# Altium schematic sheet DE-180824_1.SchDoc
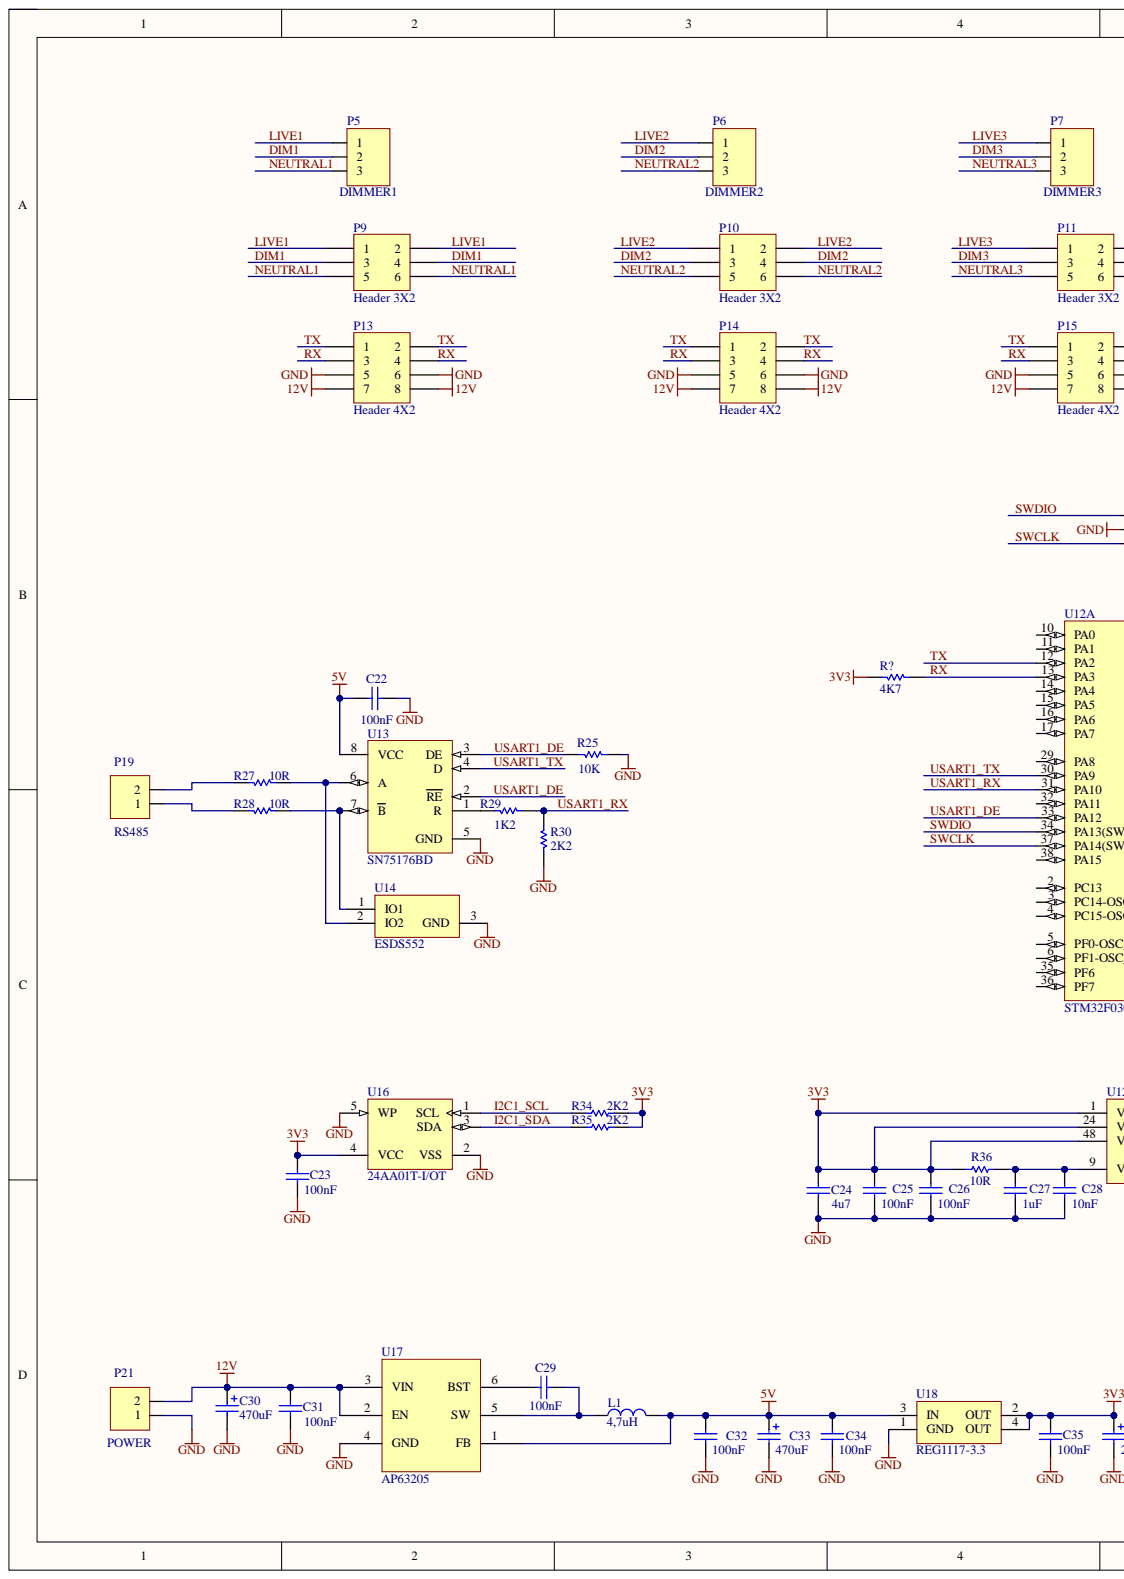
[diagram p0: DE-180824_1.SchDoc, left]
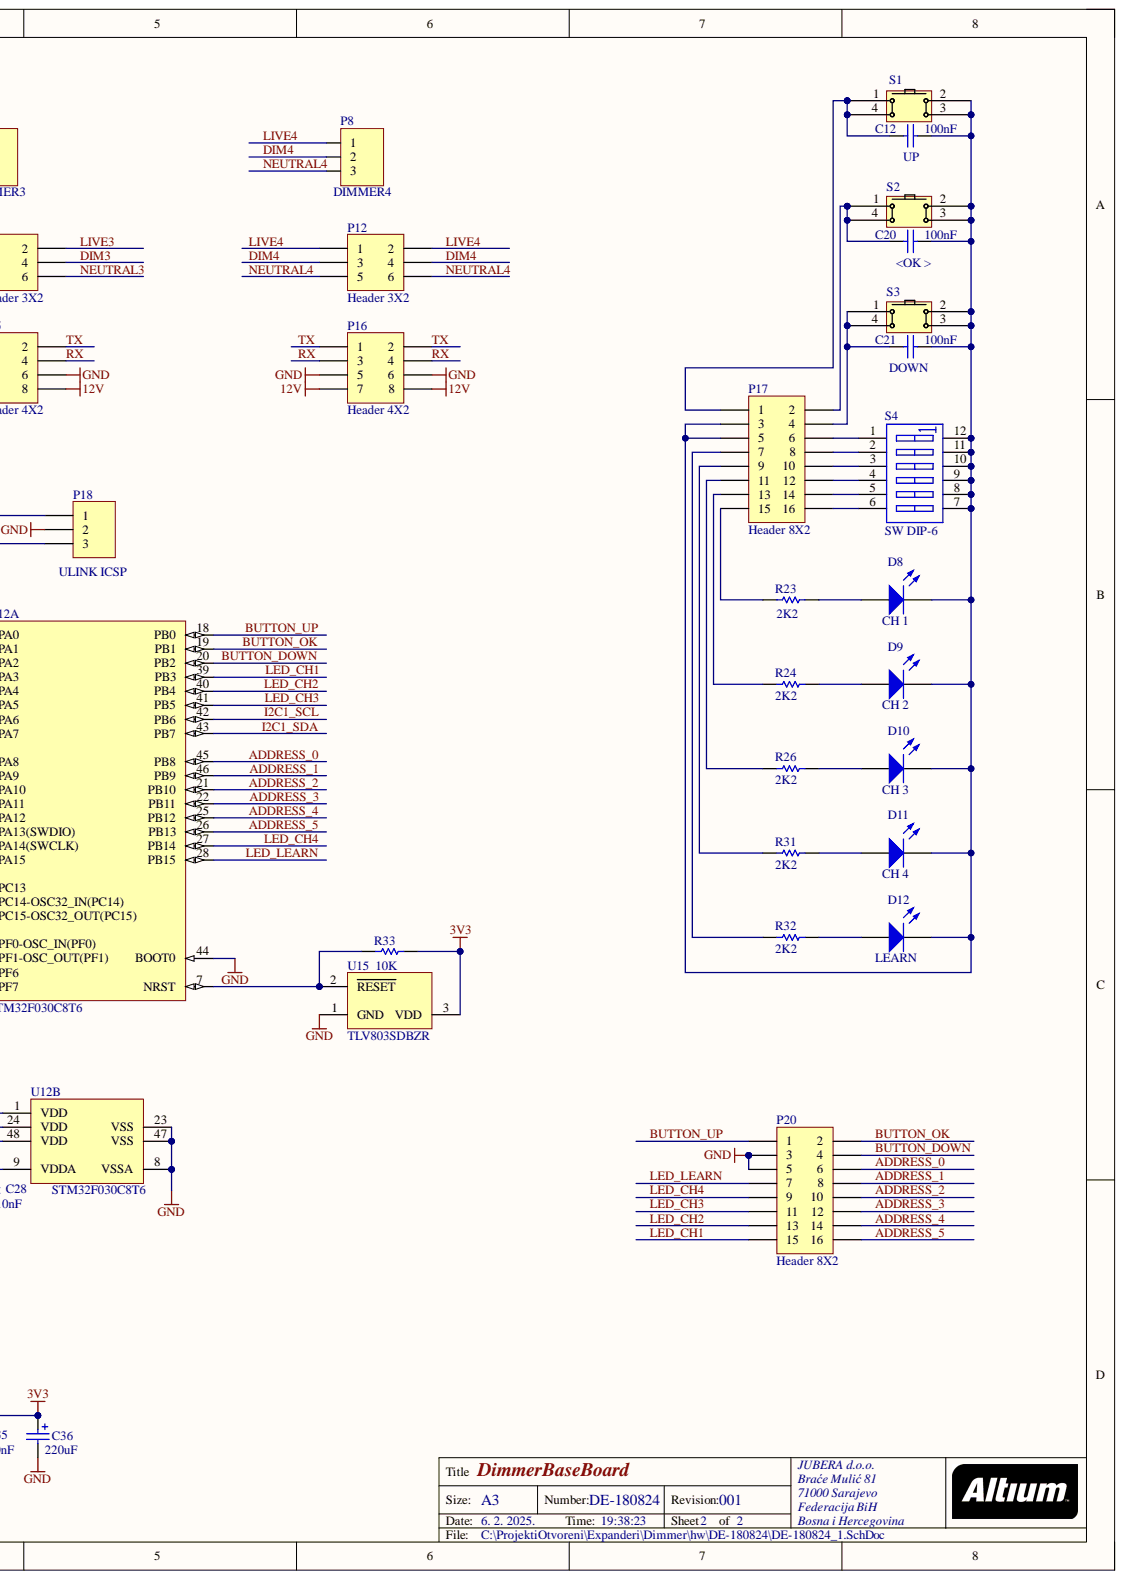
[diagram p1: DE-180824_1.SchDoc, right]

## Parts
C12  value 100nF  [0603]  (hidden comment: C0603)
C20  value 100nF  [0603]  (hidden comment: C0603)
C21  value 100nF  [0603]  (hidden comment: C0603)
C22  100nF  [0603]
C23  value 100nF  [0603]
C24  4u7  [0805]
C25  100nF  [0603]
C26  100nF  [0603]
C27  1uF  [0603]
C28  10nF  [0603]
C29  value 100nF  [0603]
C30  470uF  [ROQANG VT1V471M1010]
C31  value 100nF  [0603]
C32  value 100nF  [0603]
C33  470uF  [SMD,D6.3xL7.7mm Aluminum Electrolytic Capacitors]
C34  value 100nF  [0603]
C35  value 100nF  [0603]
C36  value 220uF  [SMD,D6.3xL7.7mm Aluminum Electrolytic Capacitors]
D8  CH 1  [1.6X0.8X0.8]
D9  CH 2  [1.6X0.8X0.8]
D10  CH 3  [1.6X0.8X0.8]
D11  CH 4  [1.6X0.8X0.8]
D12  LEARN  [1.6X0.8X0.8]
L1  value 4,7uH  [XRNR4030-4.7uH/M]
P5  DIMMER1  [TERMINAL BLOK 3]
P6  DIMMER2  [TERMINAL BLOK 3]
P7  DIMMER3  [TERMINAL BLOK 3]
P8  DIMMER4  [TERMINAL BLOK 3]
P9  Header 3X2  [HDR2X3]
P10  Header 3X2  [HDR2X3]
P11  Header 3X2  [HDR2X3]
P12  Header 3X2  [HDR2X3]
P13  Header 4X2  [HDR2X4]
P14  Header 4X2  [HDR2X4]
P15  Header 4X2  [HDR2X4]
P16  Header 4X2  [HDR2X4]
P17  Header 8X2  [HDR2X8]
P18  ULINK ICSP  [HDR1X3]
P19  RS485  [TERMINAL BLOK 2]
P20  Header 8X2  [HDR2X8]
P21  POWER  [TERMINAL BLOK 2]
R23  2K2  [ERA-0603]
R24  2K2  [ERA-0603]
R25  10K  [ERA-0603]
R26  2K2  [ERA-0603]
R27  10R  [ERA-0603]
R28  10R  [ERA-0603]
R29  1K2  [ERA-0603]
R30  2K2  [ERA-0603]
R31  2K2  [ERA-0603]
R32  2K2  [ERA-0603]
R33  10K  [ERA-0603]
R34  2K2  [ERA-0603]
R35  2K2  [ERA-0603]
R36  10R  [ERA-0603]
R?  value 4K7  [ERA-0603]  (hidden comment: 10K)
S1  UP  [TACTILE_SWITCH]
S2  <OK >  [TACTILE_SWITCH]
S3  DOWN  [TACTILE_SWITCH]
S4  SW DIP-6  [DIP-12/SW]
U12  STM32F030C8T6  [STM-LQFP48_N]  (parts A,B)
U13  SN75176BD  [D008_N]
U14  ESDS552  [DCK3_N]
U15  TLV803SDBZR  [DBZ3_N]
U16  24AA01T-I/OT  [SOT-23-OT5_N]
U17  AP63205  [TSOT-26]
U18  REG1117-3.3  [ZZ311]

## Nets
3V3: C23.2 C24.1 C25.1 C26.1 C35.2 C36.1 R33.2 R34.2 R35.2 R36.2 R?.1 U12.1(VDD) U12.24(VDD) U12.48(VDD) U15.3 U16.4(VCC) U18.2(OUT) U18.4(OUT)
5V: C22.1 C32.2 C33.1 C34.2 L1.1 U13.8(VCC) U17.1(FB) U18.3(IN)
12V: C30.1 C31.2 P13.7 P13.8 P14.7 P14.8 P15.7 P15.8 P16.7 P16.8 P21.2 U17.2(EN) U17.3(VIN)
GND: C22.2 C23.1 C24.2 C25.2 C26.2 C27.2 C28.2 C30.2 C31.1 C32.1 C33.2 C34.1 C35.1 C36.2 P13.5 P13.6 P14.5 P14.6 P15.5 P15.6 P16.5 P16.6 P18.2 P20.3 P20.5 P21.1 R25.1 R30.2 U12.8(VSSA) U12.23(VSS) U12.44(BOOT0) U12.47(VSS) U13.5(GND) U14.3 U15.1 U16.2(VSS) U16.5(WP) U17.4(GND) U18.1(GND)
ADDRESS_0: P20.6 U12.45(PB8)
ADDRESS_1: P20.8 U12.46(PB9)
ADDRESS_2: P20.10 U12.21(PB10)
ADDRESS_3: P20.12 U12.22(PB11)
ADDRESS_4: P20.14 U12.25(PB12)
ADDRESS_5: P20.16 U12.26(PB13)
BUTTON_DOWN: P20.4 U12.20(PB2)
BUTTON_OK: P20.2 U12.19(PB1)
BUTTON_UP: P20.1 U12.18(PB0)
DIM1: P5.2 P9.3 P9.4
DIM2: P6.2 P10.3 P10.4
DIM3: P7.2 P11.3 P11.4
DIM4: P8.2 P12.3 P12.4
I2C1_SCL: R34.1 U12.42(PB6) U16.1(SCL)
I2C1_SDA: R35.1 U12.43(PB7) U16.3(SDA)
LED_CH1: P20.15 U12.39(PB3)
LED_CH2: P20.13 U12.40(PB4)
LED_CH3: P20.11 U12.41(PB5)
LED_CH4: P20.9 U12.27(PB14)
LED_LEARN: P20.7 U12.28(PB15)
LIVE1: P5.1 P9.1 P9.2
LIVE2: P6.1 P10.1 P10.2
LIVE3: P7.1 P11.1 P11.2
LIVE4: P8.1 P12.1 P12.2
NEUTRAL1: P5.3 P9.5 P9.6
NEUTRAL2: P6.3 P10.5 P10.6
NEUTRAL3: P7.3 P11.5 P11.6
NEUTRAL4: P8.3 P12.5 P12.6
RX: P13.3 P13.4 P14.3 P14.4 P15.3 P15.4 P16.3 P16.4 R?.2 U12.13(PA3)
SWCLK: P18.3 U12.37(PA14(SWCLK))
SWDIO: P18.1 U12.34(PA13(SWDIO))
TX: P13.1 P13.2 P14.1 P14.2 P15.1 P15.2 P16.1 P16.2 U12.12(PA2)
USART1_DE: R25.2 U12.33(PA12) U13.2(RE) U13.3(DE)
USART1_RX: R29.1 R30.1 U12.31(PA10)
USART1_TX: U12.30(PA9) U13.4(D)
NetC12_1: C12.1 P17.1 S1.1 S1.4
NetC12_2: C12.2 C20.2 C21.2 D8.2 D9.2 D10.2 D11.2 D12.2 P17.3 P17.5 S1.2 S1.3 S2.2 S2.3 S3.2 S3.3 S4.7 S4.8 S4.9 S4.10 S4.11 S4.12
NetC20_1: C20.1 P17.2 S2.1 S2.4
NetC21_1: C21.1 P17.4 S3.1 S3.4
NetC27_1: C27.1 C28.1 R36.1 U12.9(VDDA)
NetC29_1: C29.1 L1.2 U17.5(SW)
NetC29_2: C29.2 U17.6(BST)
NetD8_1: D8.1 R23.2
NetD9_1: D9.1 R24.2
NetD10_1: D10.1 R26.2
NetD11_1: D11.1 R31.2
NetD12_1: D12.1 R32.2
NetP17_6: P17.6 S4.1
NetP17_7: P17.7 R32.1
NetP17_8: P17.8 S4.2
NetP17_9: P17.9 R31.1
NetP17_10: P17.10 S4.3
NetP17_11: P17.11 R26.1
NetP17_12: P17.12 S4.4
NetP17_13: P17.13 R24.1
NetP17_14: P17.14 S4.5
NetP17_15: P17.15 R23.1
NetP17_16: P17.16 S4.6
NetP19_1: P19.1 R28.1
NetP19_2: P19.2 R27.1
NetR27_2: R27.2 U13.6(A) U14.2
NetR28_2: R28.2 U13.7(B) U14.1
NetR29_2: R29.2 U13.1(R)
NetR33_1: R33.1 U12.7(NRST) U15.2

Not connected: U12 2(PC13) 3(PC14-OSC32_IN(PC14)) 4(PC15-OSC32_OUT(PC15)) 5(PF0-OSC_IN(PF0)) 6(PF1-OSC_OUT(PF1)) 10(PA0) 11(PA1) 14(PA4) 15(PA5) 16(PA6) 17(PA7) 29(PA8) 32(PA11) 35(PF6) 36(PF7) 38(PA15)

## Library data of the parts
C12 C20-C23 C25-C28 C31 C35 = C0603: Ceramic Chip Capacitor - Standard; Note: Footprint corresponds to dimensions for bulk cassette packaging. This case size has tightened tolerances.; Code_IPC: 1608 [0603]; Code_JEITA: 0603; Component Kind: Standard; ComponentLink1Description: Manufacturer Link; ComponentLink1URL: http://www.kemet.com/; ComponentLink2Description: Datasheet; ComponentLink2URL: http://www.kemet.com/kemet/web/homepage/kechome.nsf/vapubfilesname/F3102TA.pdf/$file/F3102TA.pdf; DatasheetDocument: Mar-2001; LatestRevisionDate: 19-Mar-2004; LatestRevisionNote: Silkscreen size reduced.; PackageDocument: Mar-2001; PackageReference: 0603; Published: 17-Jul-2002; Signal Integrity: C0603; Simulation: CAP; Publisher: Altium Limited
  C12 C20 C21 C23 C31 C35: Library Name: DE-180824.SchLib
  C22: Library Name: DE-220817.SchLib; Value: 100nF
  C25 C26: Library Name: KEMET Chip Capacitor.IntLib; Value: 100nF
  C27: Library Name: KEMET Chip Capacitor.IntLib; Value: 1uF
  C28: Library Name: KEMET Chip Capacitor.IntLib; Value: 10nF
C24 = C0805: Ceramic Chip Capacitor - Standard; Note: Footprint corresponds to dimensions for bulk cassette packaging. This case size has tightened tolerances.; Code_IPC: 2012[0805]; Code_JEITA: 0805; Component Kind: Standard; ComponentLink1Description: Manufacturer Link; ComponentLink1URL: http://www.kemet.com/; ComponentLink2Description: Datasheet; ComponentLink2URL: http://www.kemet.com/kemet/web/homepage/kechome.nsf/vapubfilesname/F3102TA.pdf/$file/F3102TA.pdf; DatasheetDocument: Mar-2001; LatestRevisionDate: 19-Mar-2004; LatestRevisionNote: Silkscreen size reduced.; Library Name: KEMET Chip Capacitor.IntLib; PackageDocument: Mar-2001; PackageReference: 0805; Published: 17-Jul-2002; Signal Integrity: C0805; Simulation: CAP; Value: 4u7; Publisher: Altium Limited
C29 C32 C34 = C0603: Ceramic Chip Capacitor - 25V; Note: Footprint corresponds to dimensions for bulk cassette packaging. This case size has tightened tolerances.; Code_IPC: 1608 [0603]; Code_JEITA: 0603; Component Kind: Standard; ComponentLink1Description: Manufacturer Link; ComponentLink1URL: http://www.kemet.com/; ComponentLink2Description: Datasheet; ComponentLink2URL: http://www.kemet.com/kemet/web/homepage/kechome.nsf/vapubfilesname/F3102TA.pdf/$file/F3102TA.pdf; DatasheetDocument: Mar-2001; LatestRevisionDate: 19-Mar-2004; LatestRevisionNote: Silkscreen size reduced.; Library Name: DE-180824.SchLib; PackageDocument: Mar-2001; PackageReference: 0603; Published: 17-Jul-2002; Signal Integrity: C0603; Simulation: CAP; Publisher: Altium Limited
C30 = ROQANG VT1C221M0607: 220uF 16V 110mA@120Hz ±20% SMD,D6.3xL7.7mm Aluminum Electrolytic Capacitors - SMD ROHS; DrillSize: Nominal, Rounded To The Nearest 0.1mm; Note: Refer to Manufacturer's Data Sheets for other lead formations; Value: 10uF; Component Kind: Standard; ComponentLink1Description: Manufacturer Link; ComponentLink1URL: http://www.vishay.com/; ComponentLink2Description: Datasheet; ComponentLink2URL: http://www.vishay.com/docs/25014/eka.pdf; DatasheetDocument: 20-Feb-2001; Library Name: DE-180824.SchLib; PackageReference: CAPPR2-5X11; Published: 19-Mar-2004; Publisher: Altium Limited
C33 C36 = ROQANG VT1C221M0607: 220uF 16V 110mA@120Hz ±20% SMD,D6.3xL7.7mm Aluminum Electrolytic Capacitors - SMD ROHS; DrillSize: Nominal, Rounded To The Nearest 0.1mm; Note: Refer to Manufacturer's Data Sheets for other lead formations; Component Kind: Standard; ComponentLink1Description: Manufacturer Link; ComponentLink1URL: http://www.vishay.com/; ComponentLink2Description: Datasheet; ComponentLink2URL: http://www.vishay.com/docs/25014/eka.pdf; DatasheetDocument: 20-Feb-2001; Library Name: DE-180824.SchLib; PackageReference: CAPPR2-5X11; Published: 19-Mar-2004; Publisher: Altium Limited
  C33: Value: 470uF
D8-D12 = HSMZ-C190: High Performance Chip LED, Red; LuminousIntensity: 40mcd (Minimum).; PCB_Layout: Complies with manufacturer's recommendation.; Component Kind: Standard; ComponentLink1Description: Manufacturer Link; ComponentLink1URL: http://www.agilent.com/; ComponentLink2Description: Datasheet; ComponentLink2URL: http://cp.literature.agilent.com/litweb/pdf/5988-5891EN.pdf; DatasheetDocument: 11-Mar-2002; LED_Colour: Red; Library Name: DE-180824.SchLib; PackageDocument: 11-Mar-2002; PackageReference: 1.6X0.8X0.8; Published: 11-Dec-2002; Signal Integrity: LED; Simulation: Agl_HSMZC110; Publisher: Altium Limited
L1 = Inductor: 2A 4.7uH Magnetic Adhesive Inductor ±20% 2.9A SMD-2P,4x4mm Power Inductors ROHS; Component Kind: Standard; Library Name: DE-180824.SchLib
P5-P8 = TERMINAL BLOK 3: 3 pinski terminal blok konektor; Component Kind: Standard; Library Name: DE-180824.SchLib
P9-P12 = Header 3X2: Header, 3-Pin, Dual row; Component Kind: Standard; LatestRevisionDate: 17-Jul-2002; LatestRevisionNote: Re-released for DXP Platform.; Library Name: Miscellaneous Connectors.IntLib; Signal Integrity: Connector; Publisher: Altium Limited
P13-P16 = Header 4X2: Header, 4-Pin, Dual row; Component Kind: Standard; LatestRevisionDate: 17-Jul-2002; LatestRevisionNote: Re-released for DXP Platform.; Library Name: Miscellaneous Connectors.IntLib; Signal Integrity: Connector; Publisher: Altium Limited
P17 P20 = Header 8X2: Header, 8-Pin, Dual row; Component Kind: Standard; LatestRevisionDate: 17-Jul-2002; LatestRevisionNote: Re-released for DXP Platform.; Library Name: Miscellaneous Connectors.IntLib; Signal Integrity: Connector; Publisher: Altium Limited
P18 = Header 3: Header, 3-Pin; Component Kind: Standard; LatestRevisionDate: 17-Jul-2002; LatestRevisionNote: Re-released for DXP Platform.; Library Name: DE-180824.SchLib; Signal Integrity: Connector; Publisher: Altium Limited
P19 P21 = TERMINAL BLOK 2: Terminal blok konektor 3,5 mm; Component Kind: Standard; Library Name: DE-180824.SchLib
R23 R24 R26 R31-R35 = ERA3Y: Rectangular Thick Film Chip Resistor, 10 Ohm to 330k Ohm Range, 0.1% and 0.5% Tolerance, 0603 Size, 0.063 W; Note: If flow soldering, adjust pad width of PCB Footprint to 0.7 - 0.8 times the width of the resistor.; Code_IPC: 1608 [0603]; Component Kind: Standard; ComponentLink1Description: Manufacturer Link; ComponentLink1URL: http://www.panasonic.com/industrial/components/resistive_products.htm; ComponentLink2Description: Datasheet; ComponentLink2URL: http://www.panasonic.com/industrial/components/pdf/AOA0000CE8.pdf; LatestRevisionDate: 19-Mar-2004; LatestRevisionNote: Silkscreen size reduced.; PackageDocument: Mar-2003; PackageReference: ERA-0603; Published: 17-Dec-2003; Signal Integrity: Res; Simulation: RESISTOR; Publisher: Altium Limited
  R23 R24 R26 R31 R32: Library Name: DE-180824.SchLib
  R33: Library Name: DE-120824.SchLib; Value: 10K
  R34 R35: Library Name: DE-120824.SchLib; Value: 2K2
R25 R27-R30 R? = ERAV: Metal Film Thermosensitive Chip Resistor, 0.56 Ohm to 10k Ohm Range, 5% Tolerance, 0603 Size, 0.063 W; Note: If flow soldering, adjust pad width of PCB Footprint to 0.7 - 0.8 times the width of the resistor.; Code_IPC: 1608 [0603]; Component Kind: Standard; ComponentLink1Description: Manufacturer Link; ComponentLink1URL: http://www.panasonic.com/industrial/components/resistive_products.htm; ComponentLink2Description: Datasheet; ComponentLink2URL: http://www.panasonic.com/industrial/components/pdf/AOF0000CE1.pdf; LatestRevisionDate: 19-Mar-2004; LatestRevisionNote: Silkscreen size reduced.; Library Name: DE-220817.SchLib; PackageDocument: Mar-2003; PackageReference: ERA-0603; Published: 17-Dec-2003; Signal Integrity: Res; Simulation: RESISTOR; Publisher: Altium Limited
  R25: Value: 10K
  R27 R28: Value: 10R
  R29: Value: 1K2
  R30: Value: 2K2
R36 = ERA6Y: Rectangular Thick Film Chip Resistor, 10 Ohm to 1M Ohm Range, 0.1% and 0.5% Tolerance, 0805 Size, 0.1 W; Note: If flow soldering, adjust pad width of PCB Footprint to 0.7 - 0.8 times the width of the resistor.; Code_IPC: 2012 [0805]; Component Kind: Standard; ComponentLink1Description: Manufacturer Link; ComponentLink1URL: http://www.panasonic.com/industrial/components/resistive_products.htm; ComponentLink2Description: Datasheet; ComponentLink2URL: http://www.panasonic.com/industrial/components/pdf/AOA0000CE8.pdf; LatestRevisionDate: 19-Mar-2004; LatestRevisionNote: Silkscreen size reduced.; Library Name: DE-280817.SchLib; PackageDocument: Mar-2003; PackageReference: ERA-0805; Published: 17-Dec-2003; Signal Integrity: Res; Simulation: RESISTOR; Value: 10R; Publisher: Altium Limited
S1-S3 = TACKTILE_SWITCH: Component Kind: Standard; Library Name: DE-180824.SchLib
S4 = SW DIP-6: DIP Switch, 6 Position, SPST; Component Kind: Standard; LatestRevisionDate: 17-Jul-2002; LatestRevisionNote: Re-released for DXP Platform.; Library Name: Miscellaneous Devices.IntLib; PackageDescription: DIP Switch; 12 Leads; PackageReference: DIP-12/SW; Published: 24-Mar-1999; Simulation: dpsw6; Publisher: Altium Limited
U12 = STM32F030C8T6: ARM Cortex-M0 32-bit MCU, 64 KB Flash, 8 KB Internal RAM, 39 I/Os, 48-pin LQFP, -40 to 85 degC, Tray; FLASH Size (Prog) (kB): 64; I/Os (High Current): 39; Internal RAM Size (kB): 8; Operating Frequency(F) (Processor speed) (MHz): 48; Supply Voltage(Vcc) min (V): 2.4; Component Kind: Standard; ComponentLink1Description: Manufacturer URL; ComponentLink1URL: http://www.st.com/web/en/home.html; ComponentLink2Description: Datasheet; ComponentLink2URL: http://www.st.com/st-web-ui/static/active/en/resource/technical/document/datasheet/DM00088500.pdf; ComponentLink3Description: Package Specification; ComponentLink3URL: http://www.st.com/st-web-ui/static/active/en/resource/technical/document/datasheet/DM00058181.pdf; Core: ARM Cortex-M0; DatasheetVersion: Rev. 1; Library Name: STMicroelectronics STM32 F0.IntLib; Mounting Technology: Surface Mount; PackageDescription: 48-Pin Low Profile Quad Flat Package, 7 x 7 mm Body, 0.5 mm Pitch; PackageReference: LQFP48; PackageVersion: Rev. 6, 06/2013; Packing: Tray; PartNumber: STM32F030C8T6; Supplier 1: Digi-Key; Supplier 2: Newark; Supplier 3: Mouser; Supplier Part Number 1: 497-14043-ND; Supplier Part Number 2: 06X3647; Supplier Part Number 3: 511-STM32F030C8T6
U13 = SN75176BD: Differential Bus Transceiver; Note: Receiver Hysteresis of 50mV Typical; Code_IPC: SOIC127P600-8; Component Kind: Standard; ComponentLink1Description: Manufacturer Link; ComponentLink1URL: http://www.ti.com/; ComponentLink2Description: Datasheet; ComponentLink2URL: http://www-s.ti.com/sc/ds/sn75176b.pdf; DatasheetVersion: Sep-1989; LatestRevisionDate: 13-Apr-2006; LatestRevisionNote: IPC-7351 Footprint Added.; Library Name: DE-010824.SchLib; PackageDescription: 8-Pin Small Outline Integrated Circuit 1.27 mm Pitch; PackageReference: D008; PackageVersion: Jan-1998; PCB3D: D008; Published: 15-May-2002; Publisher: Altium Limited
U14 = TPD2E007DCKR: ESD Protection Array for AC Signal Data Interface, 2 Channels, -40 to +85 degC, 3-pin SC70 (DCK), Green (RoHS & no Sb/Br); Manufacturer: Texas Instruments; Breakdown Voltage(Min)(V): +/-14; IEC 61000-4-2 Air-Gap(+/- kV): 15; IEC 61000-4-2 Contact(+/- kV): 8; IO Leakage Current(nA): 50; SubFamily: ESD Solution; Component Kind: Standard; ComponentLink1Description: Datasheet; ComponentLink1URL: http://www.ti.com/general/docs/lit/getliterature.tsp?genericPartNumber=TPD2E007&fileType=pdf; ComponentLink2Description: URL Link1; ComponentLink2URL: SamplesURL:http://www.ti.com/product/tpd2e007#samples; DatasheetVersion: SLVS796E; Eco-Plan: Green (RoHS & no Sb/Br); IO Capacitance(Typ)(pF): 15; Library Name: TI Interface ESD EMI Protection.IntLib; Mounting Technology: Surface Mount; PackageDescription: 3-Pin Plastic Small-Outline Package, 0.65 mm Pitch, 2.1 mm Lead Span; PackageReference: DCK3; PackageVersion: revG, Jan-2007; PartNumber: TPD2E007DCKR; Supplier 1: Digi-Key; Supplier 2: Digi-Key; Supplier 3: Digi-Key; Supplier 4: Farnell; Supplier 5: Newark; Supplier 6: Newark; Supplier 7: Mouser; Supplier Part Number 1: 296-25281-2-ND; Supplier Part Number 2: 296-25281-1-ND; Supplier Part Number 3: 296-25281-6-ND; Supplier Part Number 4: 2120465; Supplier Part Number 5: 94T5089; Supplier Part Number 6: 55R7554; Supplier Part Number 7: 595-TPD2E007DCKR
U15 = TLV803MDBZR: Voltage Supervisor with Active-Low, Open Drain Reset, 1 Supply Monitored, -40 to 125 degC, 3-pin SOT-23 (DBZ), Green (RoHS & no Sb/Br); Manufacturer: Texas Instruments; # of supplies monitored: 1; Iq(Typ)(uA): 9; Output Driver Type / Reset Output: Active-low, Open-drain; Rating: Catalog; Reset Threshold Accuracy(%): 2; SubFamily: Supervisor and Reset IC; Threshold Voltage 1 (typ)(Typ)(V): 4.38; Time Delay(ms): 200; VCC(Max)(V): 6; VCC(Min)(V): 1.1; Code_JEDEC: TO-236-AB; Component Kind: Standard; ComponentLink1Description: Datasheet; ComponentLink1URL: http://www.ti.com/general/docs/lit/getliterature.tsp?genericPartNumber=TLV803M&fileType=pdf; DatasheetVersion: SBVS157B; Library Name: TI Power Management Supervisor and Reset IC.IntLib; Mounting Technology: Surface Mount; PackageDescription: 3-Pin Plastic Small-Outline Package, 0.95 mm Pitch, 2.4 mm Lead Span; PackageReference: DBZ3; PackageVersion: revB, Apr-2005; PartNumber: TLV803MDBZR; Supplier 1: Digi-Key; Supplier 2: Digi-Key; Supplier 3: Digi-Key; Supplier 4: Newark; Supplier 5: Mouser; Supplier Part Number 1: 296-29194-2-ND; Supplier Part Number 2: 296-29194-1-ND; Supplier Part Number 3: 296-29194-6-ND; Supplier Part Number 4: 58T0286; Supplier Part Number 5: 595-TLV803MDBZR
U16 = 24AA01T-I/OT: 1Kbit, 400kHz, 1.8V, I2C Serial EEPROM, 5-Pin SOT-23, Industrial Temperature, Tape and Reel; Code_IPC: SOT23-5; Component Kind: Standard; ComponentLink1Description: Datasheet; ComponentLink1URL: http://ww1.microchip.com/downloads/en/DeviceDoc/21711J.pdf; ComponentLink2Description: Packaging Specification; ComponentLink2URL: http://www.microchip.com/stellent/groups/techpub_sg/documents/packagingspec/en012702.pdf; ComponentLink3Description: Microchip.com Home; ComponentLink3URL: http://www.microchip.com/; DatasheetVersion: revJ, Jan-2009; Library Name: Microchip Memory I2C Serial EEPROM.IntLib; PackageDescription: 5-Lead Plastic Small Outline Transistor (OT) [SOT-23]; PackageReference: SOT-23-OT5; PackageVersion: revBB, Aug-2009; Published: 29-Jan-2009; Supplier 1: Digi-Key; Supplier 2: Digi-Key; Supplier 3: Digi-Key; Supplier 4: Farnell; Supplier Part Number 1: 24AA01T-I/OTTR-ND; Supplier Part Number 2: 24AA01T-I/OTCT-ND; Supplier Part Number 3: 24AA01T-I/OTDKR-ND; Supplier Part Number 4: 1331269; Publisher: Altium Limited
U17 = AP63200: 2A synchronous  buck converter; Component Kind: Standard; Library Name: DE-180824.SchLib
U18 = REG1117-3.3: 800mA and 1A Low Dropout Positive Regulator 2.85V, 3.3V, 5V, and Adjustable; Component Kind: Standard; ComponentLink1Description: Manufacturer Link; ComponentLink1URL: http://www.ti.com/; ComponentLink2Description: Datasheet; ComponentLink2URL: http://www-s.ti.com/sc/ds/REG1117.pdf; DatasheetVersion: Sep-1997; LatestRevisionDate: 17-Jul-2002; LatestRevisionNote: Re-released for DXP Platform.; Library Name: DE-180824.SchLib; PackageDescription: SOT-223/SC-73; 4 Leads; Body 6.7 x 7.3 mm, inc. leads (LxW max); PackageReference: ZZ311; PackageVersion: revC; Published: 8-Jun-2000; Publisher: Altium Limited
